# Altium schematic sheet main.SchDoc(main)
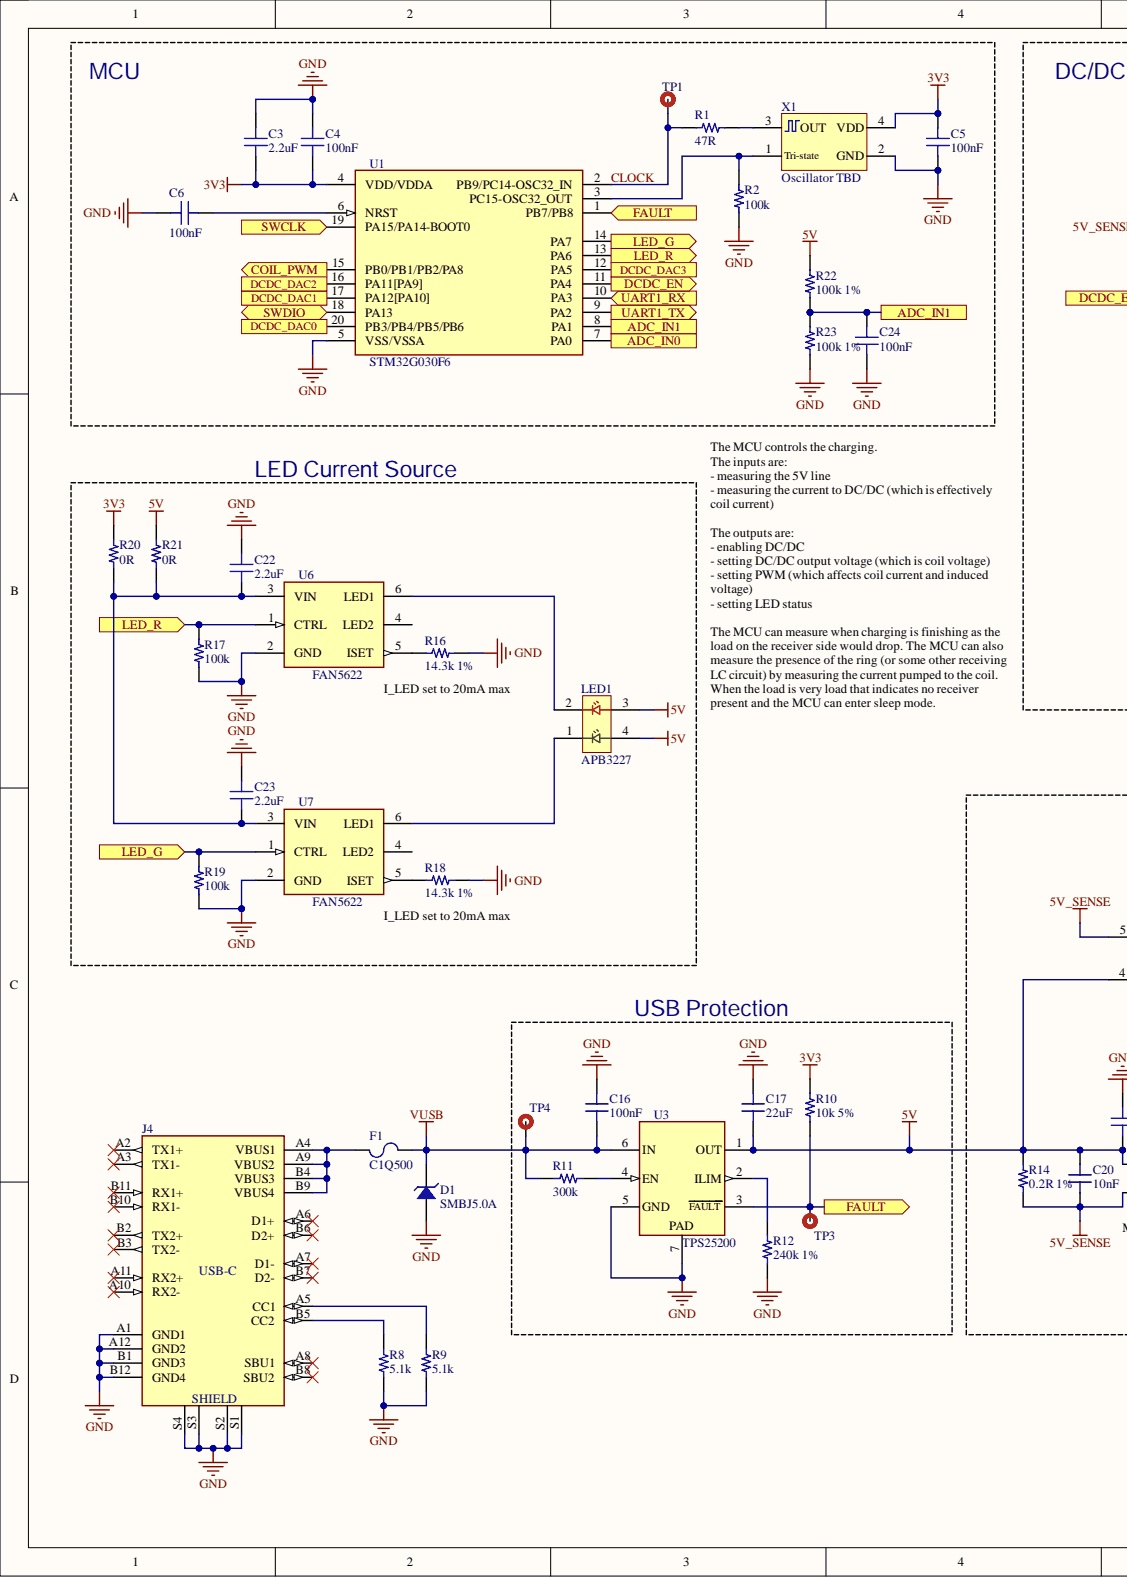
[diagram p0: main.SchDoc, left]
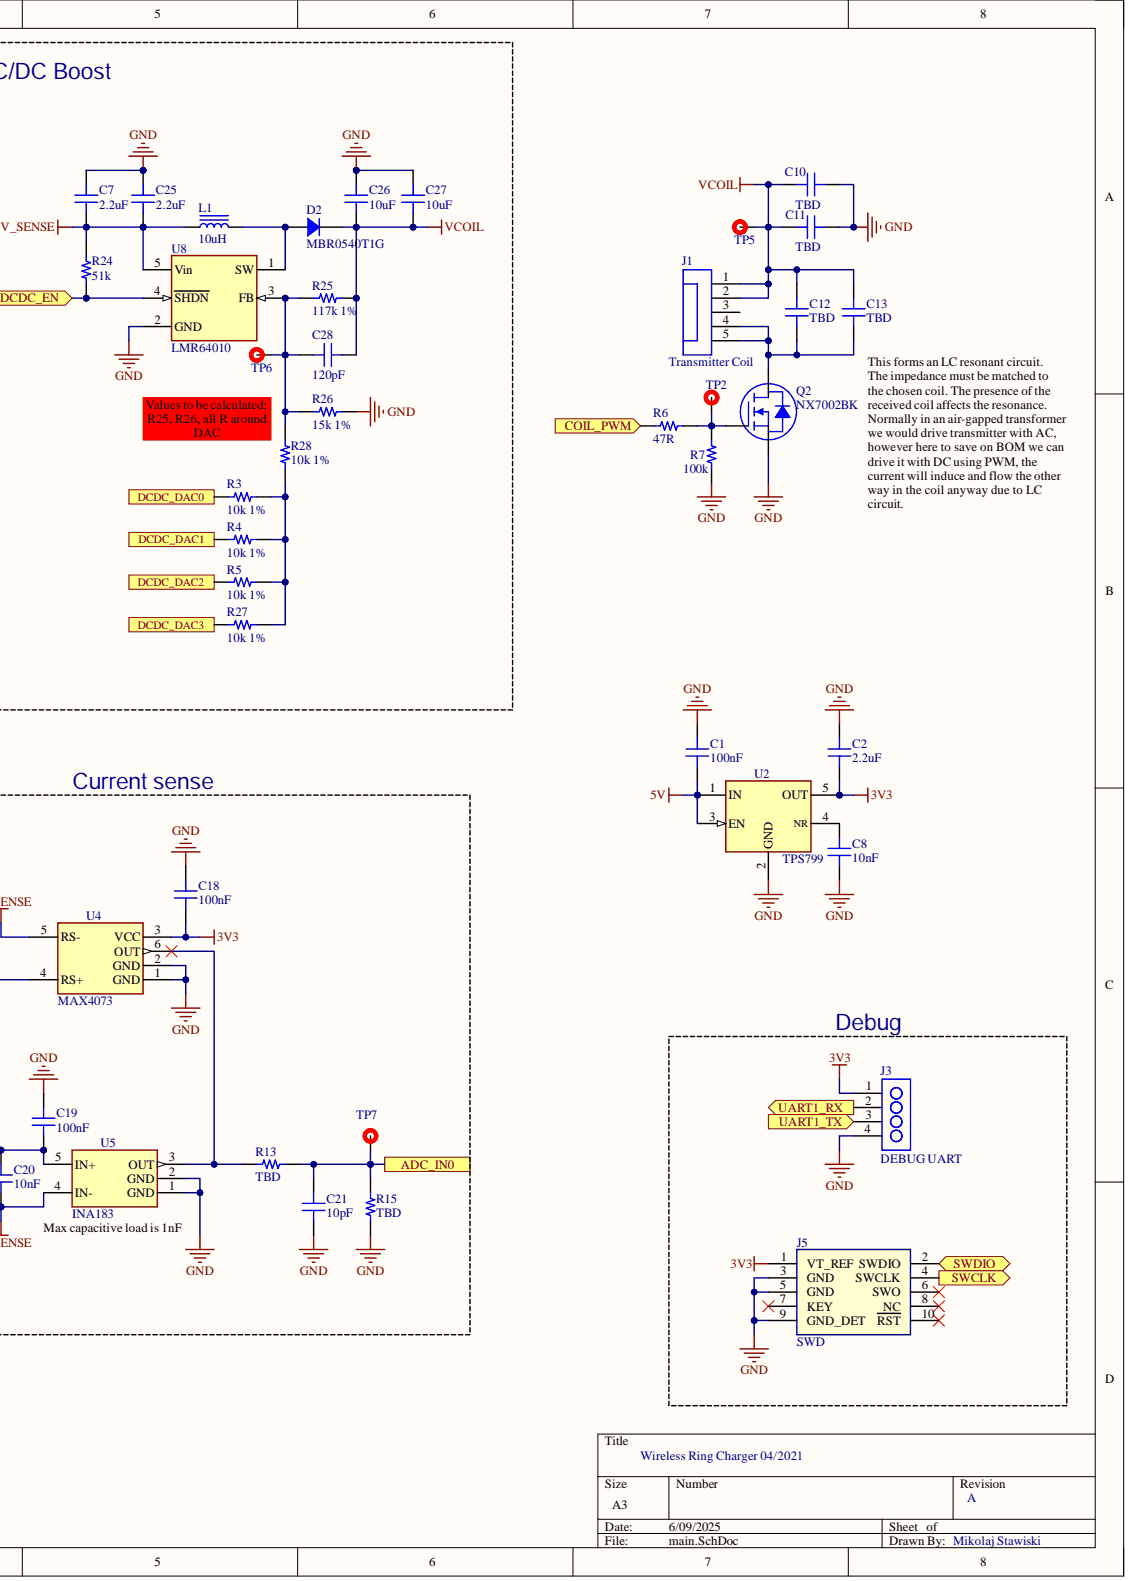
[diagram p1: main.SchDoc, right]

## Parts
C1  100nF
C2  2.2uF
C3  2.2uF
C4  100nF
C5  100nF
C6  100nF
C7  2.2uF
C8  10nF
C10  TBD
C11  TBD
C12  TBD
C13  TBD
C16  100nF
C17  22uF
C18  100nF
C19  100nF
C20  10nF
C21  10pF
C22  2.2uF
C23  2.2uF
C24  100nF
C25  2.2uF
C26  10uF
C27  10uF
C28  120pF
D1  SMBJ5.0A
D2  MBR0540T1G
F1  C1Q500
J1  Transmitter Coil
J3  DEBUG UART
J4  USB-C
J5  SWD
L1  10uH
LED1  APB3227
Q2  NX7002BK
R1  47R
R2  100k
R3  10k 1%  Tolerance: 1%
R4  10k 1%  Tolerance: 1%
R5  10k 1%  Tolerance: 1%
R6  47R
R7  100k
R8  5.1k
R9  5.1k
R10  10k 5%  Tolerance: 5%
R11  300k
R12  240k 1%
R13  TBD
R14  0.2R 1%
R15  TBD
R16  14.3k 1%  Tolerance: 1%
R17  100k
R18  14.3k 1%  Tolerance: 1%
R19  100k
R20  0R
R21  0R
R22  100k 1%  Tolerance: 1%
R23  100k 1%
R24  51k
R25  117k 1%  Tolerance: 1%
R26  15k 1%  Tolerance: 1%
R27  10k 1%  Tolerance: 1%
R28  10k 1%  Tolerance: 1%
TP1  Testpoint  (value not drawn)
TP2  Testpoint  (value not drawn)
TP3  Testpoint  (value not drawn)
TP4  Testpoint  (value not drawn)
TP5  Testpoint  (value not drawn)
TP6  Testpoint  (value not drawn)
TP7  Testpoint  (value not drawn)
U1  STM32G030F6
U2  TPS799
U3  TPS25200
U4  MAX4073
U5  INA183
U6  FAN5622
U7  FAN5622
U8  LMR64010
X1  Oscillator TBD

## Ports
ADC_IN0, ADC_IN1, COIL_PWM, DCDC_DAC0, DCDC_DAC1, DCDC_DAC2, DCDC_DAC3, DCDC_EN, FAULT, LED_G, LED_R, SWCLK, SWDIO, UART1_RX, UART1_TX

## Nets
3V3: C2.1 C3.1 C4.1 C5.2 C18.1 J3.1 J5.1(VT_REF) R10.2 R20.2 U1.4(VDD/VDDA) U2.5(OUT) U4.3(VCC) X1.4(VDD)
5V: C1.1 C17.2 C19.1 C20.2 LED1.3 LED1.4 R14.2 R21.2 R22.2 U2.1(IN) U2.3(EN) U3.1(OUT) U4.4(RS+) U5.5(IN+)
5V_SENSE: C7.1 C20.1 C25.1 L1.1 R14.1 R24.1 U4.5(RS-) U5.4(IN-) U8.5(Vin)
GND: C1.2 C2.2 C3.2 C4.2 C5.1 C6.2 C7.2 C8.1 C10.1 C11.1 C16.2 C17.1 C18.2 C19.2 C21.1 C22.2 C23.2 C24.1 C25.2 C26.2 C27.2 D1.1 J3.4 J4.A1(GND1) J4.A12(GND2) J4.B1(GND3) J4.B12(GND4) J4.S1 J4.S2 J4.S3 J4.S4 J5.3(GND) J5.5(GND) J5.9(GND_DET) Q2.S R2.1 R7.1 R8.1 R9.1 R12.1 R15.1 R16.2 R17.1 R18.2 R19.1 R23.1 R26.2 U1.5(VSS/VSSA) U2.2(GND) U3.5(GND) U3.7 U4.1(GND) U4.2(GND) U5.1(GND) U5.2(GND) U6.2(GND) U7.2(GND) U8.2(GND) X1.2(GND)
VCOIL: C10.2 C11.2 C12.2 C13.2 C26.1 C27.1 C28.1 D2.2 J1.1 J1.2 R25.2 TP5.1
VUSB: C16.1 D1.2 F1.2 R11.2 TP4.1 U3.6(IN)
ADC_IN0: C21.2 R13.1 R15.2 TP7.1 U1.7(PA0) ; port ADC_IN0
ADC_IN1: C24.2 R22.1 R23.2 U1.8(PA1) ; port ADC_IN1
CLOCK: R1.2 TP1.1 U1.2(PB9/PC14-OSC32_IN)
COIL_PWM: R6.1 U1.15(PB0/PB1/PB2/PA8) ; port COIL_PWM
DCDC_DAC0: R3.1 U1.20(PB3/PB4/PB5/PB6) ; port DCDC_DAC0
DCDC_DAC1: R4.1 U1.17(PA12[PA10]) ; port DCDC_DAC1
DCDC_DAC2: R5.1 U1.16(PA11[PA9]) ; port DCDC_DAC2
DCDC_DAC3: R27.1 U1.12(PA5) ; port DCDC_DAC3
DCDC_EN: R24.2 U1.11(PA4) U8.4(SHDN) ; port DCDC_EN
FAULT: R10.1 TP3.1 U1.1(PB7/PB8) U3.3(FAULT) ; port FAULT
LED_G: R19.2 U1.14(PA7) U7.1(CTRL) ; port LED_G
LED_R: R17.2 U1.13(PA6) U6.1(CTRL) ; port LED_R
SWCLK: J5.4(SWCLK) U1.19(PA15/PA14-BOOT0) ; port SWCLK
SWDIO: J5.2(SWDIO) U1.18(PA13) ; port SWDIO
UART1_RX: J3.2 U1.10(PA3) ; port UART1_RX
UART1_TX: J3.3 U1.9(PA2) ; port UART1_TX
NetC6_1: C6.1 U1.6(NRST)
NetC8_2: C8.2 U2.4(NR)
NetC12_1: C12.1 C13.1 J1.4 J1.5 Q2.D
NetC22_1: C22.1 C23.1 R20.1 R21.1 U6.3(VIN) U7.3(VIN)
NetC28_2: C28.2 R25.1 R26.1 R28.2 TP6.1 U8.3(FB)
NetD2_1: D2.1 L1.2 U8.1(SW)
NetF1_1: F1.1 J4.A4(VBUS1) J4.A9(VBUS2) J4.B4(VBUS3) J4.B9(VBUS4)
NetJ4_A5: J4.A5(CC1) R9.2
NetJ4_B5: J4.B5(CC2) R8.2
NetLED1_1: LED1.1 U7.6(LED1)
NetLED1_2: LED1.2 U6.6(LED1)
NetQ2_G: Q2.G R6.2 R7.2 TP2.1
NetR1_1: R1.1 X1.3(OUT)
NetR2_2: R2.2 U1.3(PC15-OSC32_OUT) X1.1(Tri-state)
NetR3_2: R3.2 R4.2 R5.2 R27.2 R28.1
NetR11_1: R11.1 U3.4(EN)
NetR12_2: R12.2 U3.2(ILIM)
NetR13_2: R13.2 U4.6(OUT) U5.3(OUT)
NetR16_1: R16.1 U6.5(ISET)
NetR18_1: R18.1 U7.5(ISET)

Not connected: J1 3; J4 A2(TX1+) A3(TX1-) A6(D1+) A7(D1-) A8(SBU1) A10(RX2-) A11(RX2+) B2(TX2+) B3(TX2-) B6(D2+) B7(D2-) B8(SBU2) B10(RX1-) B11(RX1+); J5 6(SWO) 7(KEY) 8(NC) 10(RST); U6 4(LED2); U7 4(LED2)

## Library data of the parts
C1 C4-C6 C18 C19 C24 = CAPACITOR_0402: Capacitor SMT 0402; Manufacturer: Murata; Part Number: GRM155R71H104KE14D; Tolerance: 10%; Voltage - Rated: 10V; Component Kind: Standard; Device Package: 0402 (1005 Metric); Distributor link 1: https://www.digikey.com/en/products/detail/murata-electronics/GRM155R71H104KE14D/4906220; Library Name: common.SchLib; Mounting Type: SMT; Size / Dimension: 0.039" L x 0.020" W (1.00mm x 0.50mm); Value: 100nF
C2 C3 C7 C22 C23 C25 = CAPACITOR_0603: Capacitor SMT 0603; Manufacturer: Samsung; Part Number: CL10A225KP8NNNC; Tolerance: 10%; Voltage - Rated: 10V; Component Kind: Standard; Device Package: 0603 (1608 Metric); Distributor link 1: https://www.digikey.com/en/products/detail/samsung-electro-mechanics/CL10A225KP8NNNC/3886743; Library Name: common.SchLib; Mounting Type: SMT; Size / Dimension: 0.063" L x 0.031" W (1.60mm x 0.80mm); Value: 2.2uF
C8 C20 = CAPACITOR_0402: Capacitor SMT 0402; Manufacturer: Murata; Tolerance: 10%; Voltage - Rated: 10V; Component Kind: Standard; Device Package: 0402 (1005 Metric); Library Name: common.SchLib; Mounting Type: SMT; Size / Dimension: 0.039" L x 0.020" W (1.00mm x 0.50mm); Value: 10nF
  C8: Distributor link 1: https://www.digikey.com/en/products/detail/murata-electronics/GRM155R71H104KE14D/4906220
C10-C13 = CAPACITOR_1206: Capacitor SMT 1206; Component Kind: Standard; Device Package: 1206 (3216 Metric); Library Name: common.SchLib; Mounting Type: SMT; Size / Dimension: 0.126" L x 0.063" W (3.20mm x 1.60mm); Value: TBD
C16 = CAPACITOR_0603: Capacitor SMT 0603; Tolerance: 10%; Voltage - Rated: 50V; Component Kind: Standard; Device Package: 0603 (1608 Metric); Library Name: common.SchLib; Mounting Type: SMT; Size / Dimension: 0.063" L x 0.031" W (1.60mm x 0.80mm); Value: 100nF
C17 = CAPACITOR_0805: Capacitor SMT 0805; Manufacturer: Samsung; Part Number: CL21A226MOCLRNC; Tolerance: 20%; Voltage - Rated: 16V; Component Kind: Standard; Device Package: 0805 (2012 Metric); Distributor link 1: https://www.digikey.com/en/products/detail/samsung-electro-mechanics/CL21A226MOCLRNC/5961264; Library Name: common.SchLib; Mounting Type: SMT; Size / Dimension: 0.079" L x 0.049" W (2.00mm x 1.25mm); Thickness (Max): 0.95mm; Value: 22uF
C21 = CAPACITOR_0402: Capacitor SMT 0402; Tolerance: 10%; Voltage - Rated: 10V; Component Kind: Standard; Device Package: 0402 (1005 Metric); Library Name: common.SchLib; Mounting Type: SMT; Size / Dimension: 0.039" L x 0.020" W (1.00mm x 0.50mm); Value: 10pF
C26 C27 = CAPACITOR_1206: Capacitor SMT 1206; Manufacturer: TDK; Part Number: C3216X5R1H106K160AB; Tolerance: 10%; Voltage - Rated: 50V; Component Kind: Standard; Device Package: 1206 (3216 Metric); Distributor link 1: https://www.digikey.com/en/products/detail/tdk-corporation/C3216X5R1H106K160AB/2443476; Library Name: common.SchLib; Mounting Type: SMT; Size / Dimension: 0.126" L x 0.063" W (3.20mm x 1.60mm); Value: 10uF
C28 = CAPACITOR_0402: Capacitor SMT 0402; Manufacturer: Murata; Tolerance: 10%; Voltage - Rated: 50V; Component Kind: Standard; Device Package: 0402 (1005 Metric); Library Name: common.SchLib; Mounting Type: SMT; Size / Dimension: 0.039" L x 0.020" W (1.00mm x 0.50mm); Value: 120pF
D1 = DIODE_TVS_GENERIC: Generic TVS Diode; Manufacturer: Bourns; Component Kind: Standard; Device Package: DO-214AA; Distributor link 1: https://www.digikey.com/en/products/detail/bourns-inc/SMBJ5-0A/2254202; Library Name: common.SchLib; Mounting Type: SMT; Part Number: SMBJ5.0A; Value: SMBJ5.0A
D2 = DIODE_GENERIC: Diode Generic; Component Kind: Standard; Device Package: SOD-123; Distributor link 1: https://www.digikey.com/en/products/detail/on-semiconductor/MBR0540T1G/917967; Library Name: common.SchLib; Mounting Type: SMT; Part Number: MBR0540T1G; Value: MBR0540T1G
F1 = FUSE_GENERIC: Generic Fuse; Manufacturer: Bel Fuse Inc.; Voltage - Rated: 63 VDC; Component Kind: Standard; Device Package: 1206 (3216 Metric); Distributor link 1: https://www.digikey.com/en/products/detail/bel-fuse-inc/C1Q-500/615155; Library Name: common.SchLib; Mounting Type: SMT; Part Number: C1Q500; Size / Dimension: 3.20mm x 1.58mm x 0.58mm; Value: C1Q500
J1 = CONN_FPC_5PIN: FPC connector 5-pin generic; Component Kind: Standard; Library Name: common.SchLib; Value: Transmitter Coil
J3 = CONN_4PIN: Connector 4-pin generic; Component Kind: Standard; Library Name: common.SchLib
J4 = CONN_USBC: USB-C connector; Manufacturer: Molex; Part Number: 1054500101; Component Kind: Standard; Distributor link 1: https://www.digikey.com/en/products/detail/molex/1054500101/5843890; Library Name: common.SchLib; Mounting Type: SMT; Value: USB-C
J5 = CONN_10PIN_SWD_ARM: 10-pin ARM SWD connector for debugging; Manufacturer: Samtec; Part Number: FTSH-105-01-L-DV-K; Component Kind: Standard; Library Name: common.SchLib; Value: SWD
L1 = INDUCTOR_GENERIC: Inductor Generic; Manufacturer: TDK; Part Number: MLP2520S100ST0S1; Tolerance: 20%; Component Kind: Standard; Device Package: 1008 (2520 Metric); Distributor link 1: https://www.digikey.com/en/products/detail/MLP2520S100ST0S1/445-9507-1-ND/3909680?itemSeq=362222387; Library Name: common.SchLib; Mounting Type: SMT; Size / Dimension: 0.098" L x 0.079" W (2.50mm x 2.00mm); Thickness (Max): 1.2mm; Value: 10uH
LED1 = APB3227: APB3227 3.2 x 2.7 mm Surface Mount LED Lamp; Manufacturer: Kingbright; Component Kind: Standard; Device Package: 4-SMD; Library Name: Kingbright.SchLib; Link: https://www.kingbrightusa.com/kcpartno.asp?txtPartNo=APB3227; Mounting Type: SMT; Part Number: APB3227; Value: APB3227
Q2 = MOSFET_N_GDS: N-MOSFET SMT; Component Kind: Standard; Library Name: common.SchLib; Mounting Type: SMT; Part Number: NX7002BK; Value: NX7002BK
R1 R2 R6-R9 R11 R13 R15 R17 R19 R24 = RESISTOR_0402: Resistor SMT 0402; Tolerance: 5%; Component Kind: Standard; Device Package: 0402 (1005 Metric); Library Name: common.SchLib; Mounting Type: SMT; Size / Dimension: 0.039" L x 0.020" W (1.00mm x 0.50mm)
  R1 R6: Value: 47R
  R2 R7 R17 R19: Value: 100k
  R8 R9: Value: 5.1k
  R11: Value: 300k
  R13 R15: Value: TBD
  R24: Value: 51k
R3-R5 R10 R12 R16 R18 R22 R23 R25-R28 = RESISTOR_0402: Resistor SMT 0402; Component Kind: Standard; Device Package: 0402 (1005 Metric); Library Name: common.SchLib; Mounting Type: SMT; Size / Dimension: 0.039" L x 0.020" W (1.00mm x 0.50mm)
  R3-R5 R27 R28: Value: 10k 1%
  R10: Value: 10k 5%
  R12: Tolerance: 1%; Value: 240k 1%
  R16 R18: Value: 14.3k 1%
  R22: Value: 100k 1%
  R23: Tolerance: 1%; Value: 100k 1%
  R25: Value: 117k 1%
  R26: Value: 15k 1%
R14 = RESISTOR_1206: Resistor SMT 1206; Component Kind: Standard; Device Package: 1206 (3216 Metric); Library Name: common.SchLib; Mounting Type: SMT; Size / Dimension: 0.126" L x 0.063" W (3.20mm x 1.60mm); Value: 0.2R 1%
R20 R21 = RESISTOR_0603: Resistor SMT 0603; Component Kind: Standard; Device Package: 0603 (1608 Metric); Library Name: common.SchLib; Mounting Type: SMT; Size / Dimension: 0.063" L x 0.031" W (1.60mm x 0.80mm); Value: 0R
TP1-TP7 = Testpoint: Testpoint for measuring at the PCB; Component Kind: Standard; Library Name: common.SchLib
U1 = STM32G030F6: Mainstream Value-Line Arm Cortex-M0+ MCU with 32 Kbytes of of Flash memory memory, 8 Kbytes RAM, 64 MHz CPU, 2x USART, timers, ADC, comm. I/F, 2-3.6V; Manufacturer: STM; Component Kind: Standard; Distributor link 1: https://www.digikey.com/en/products/detail/stmicroelectronics/STM32G030F6P6/10326686; Library Name: ST.SchLib; Link: https://www.st.com/content/st_com/en/products/microcontrollers-microprocessors/stm32-32-bit-arm-cortex-mcus/stm32-mainstream-mcus/stm32g0-series/stm32g0x0-value-line/stm32g030f6.html; Mounting Type: SMT; Part Number: STM32G030F6; Value: STM32G030F6
U2 = TPS799XX: TPS799 200-mA, Low-Quiescent Current, Ultralow Noise, High-PSRR Low-Dropout Linear  Regulator; Manufacturer: TI; Part Number: TPS79933DDCR‎; Component Kind: Standard; Library Name: TI.SchLib; Link: https://www.ti.com/product/TPS799; Mounting Type: SMT; Value: TPS799
U3 = TPS25200: TPS25200 5-V eFuse With Precision Adjustable Current Limit and Overvoltage Clamp; Manufacturer: TI; Component Kind: Standard; Device Package: WSON6; Distributor link 1: https://www.digikey.com/en/products/detail/texas-instruments/TPS25200DRVT/4840667; Library Name: TI.SchLib; Link: https://www.ti.com/product/TPS25200; Mounting Type: SMT; Part Number: TPS25200; Value: TPS25200
U4 = MAX4073: Low-Cost, SC70, Voltage-Output, High-Side Current-Sense Amplifier (in SC70 or SOT23); Manufacturer: Maxim Integrated; Component Kind: Standard; Device Package: SOT23-6 / SC70-5; Library Name: Maxim.SchLib; Link: https://www.maximintegrated.com/en/products/analog/amplifiers/MAX4073T.html; Mounting Type: SMT; Part Number: MAX4073; Value: MAX4073
U5 = INA183: INA183 2.7-V to 26-V, High-Precision Current Sense Amplifier; Manufacturer: TI; Component Kind: Standard; Device Package: SOT23-5; Distributor link 1: https://www.digikey.com.au/product-detail/en/texas-instruments/INA183A1IDBVR/296-INA183A1IDBVRTR-ND/13896392; Library Name: TI.SchLib; Link: https://www.ti.com/product/INA183; Mounting Type: SMT; Part Number: INA183; Value: INA183
U6 U7 = FAN5622: Linear LED Drivers with Single-Wire Digital Interface; Manufacturer: Failchild; Component Kind: Standard; Device Package: SOT23-6; Distributor link 1: https://www.digikey.com/en/products/detail/on-semiconductor/FAN5622SX/2509485; Link: https://www.onsemi.com/products/power-management/led-drivers/linear-led-drivers/fan5622sx; Mounting Type: SMT; Package / Case: SOT23-6; Part Number: FAN5622; Thickness (Max): 1.10; Value: FAN5622; Library Name: Fairchild.SchLib
U8 = LMR64010: LMR64010 SIMPLE SWITCHER 40Vout, 1A Step-Up Voltage Regulator in SOT-23; Manufacturer: TI; Part Number: LMR64010XMF; Component Kind: Standard; Device Package: SOT23-5; Distributor link 1: https://www.digikey.com/en/products/detail/LMR64010XMFX%2fNOPB/296-38771-1-ND/5125873; Library Name: TI.SchLib; Link: https://www.ti.com/product/LMR64010; Mounting Type: SMT; Value: LMR64010
X1 = OSCILLATOR_4PIN_GENERIC: Oscillator 4-pin Generic with tri-state control; Component Kind: Standard; Library Name: common.SchLib; Value: Oscillator TBD
